# Altium schematic sheet FPGA_Config.SchDoc(FPGA_Config)
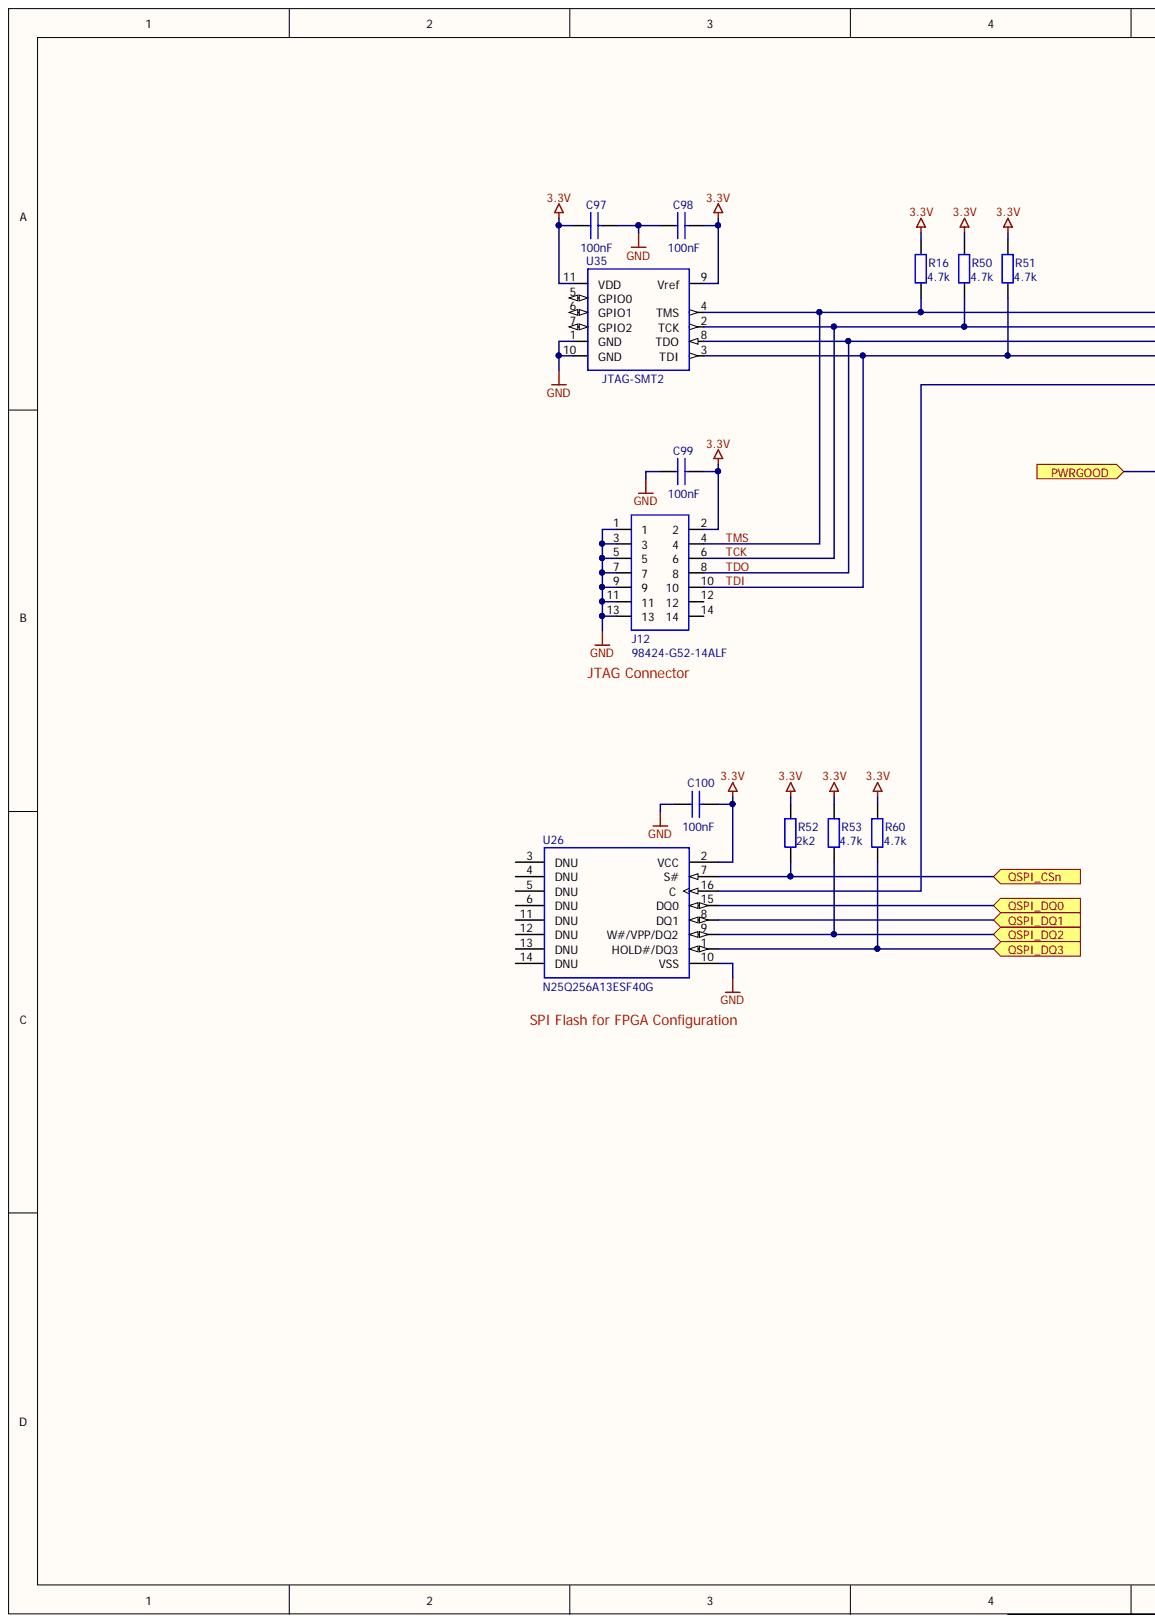
[diagram p0: FPGA_Config.SchDoc, left]
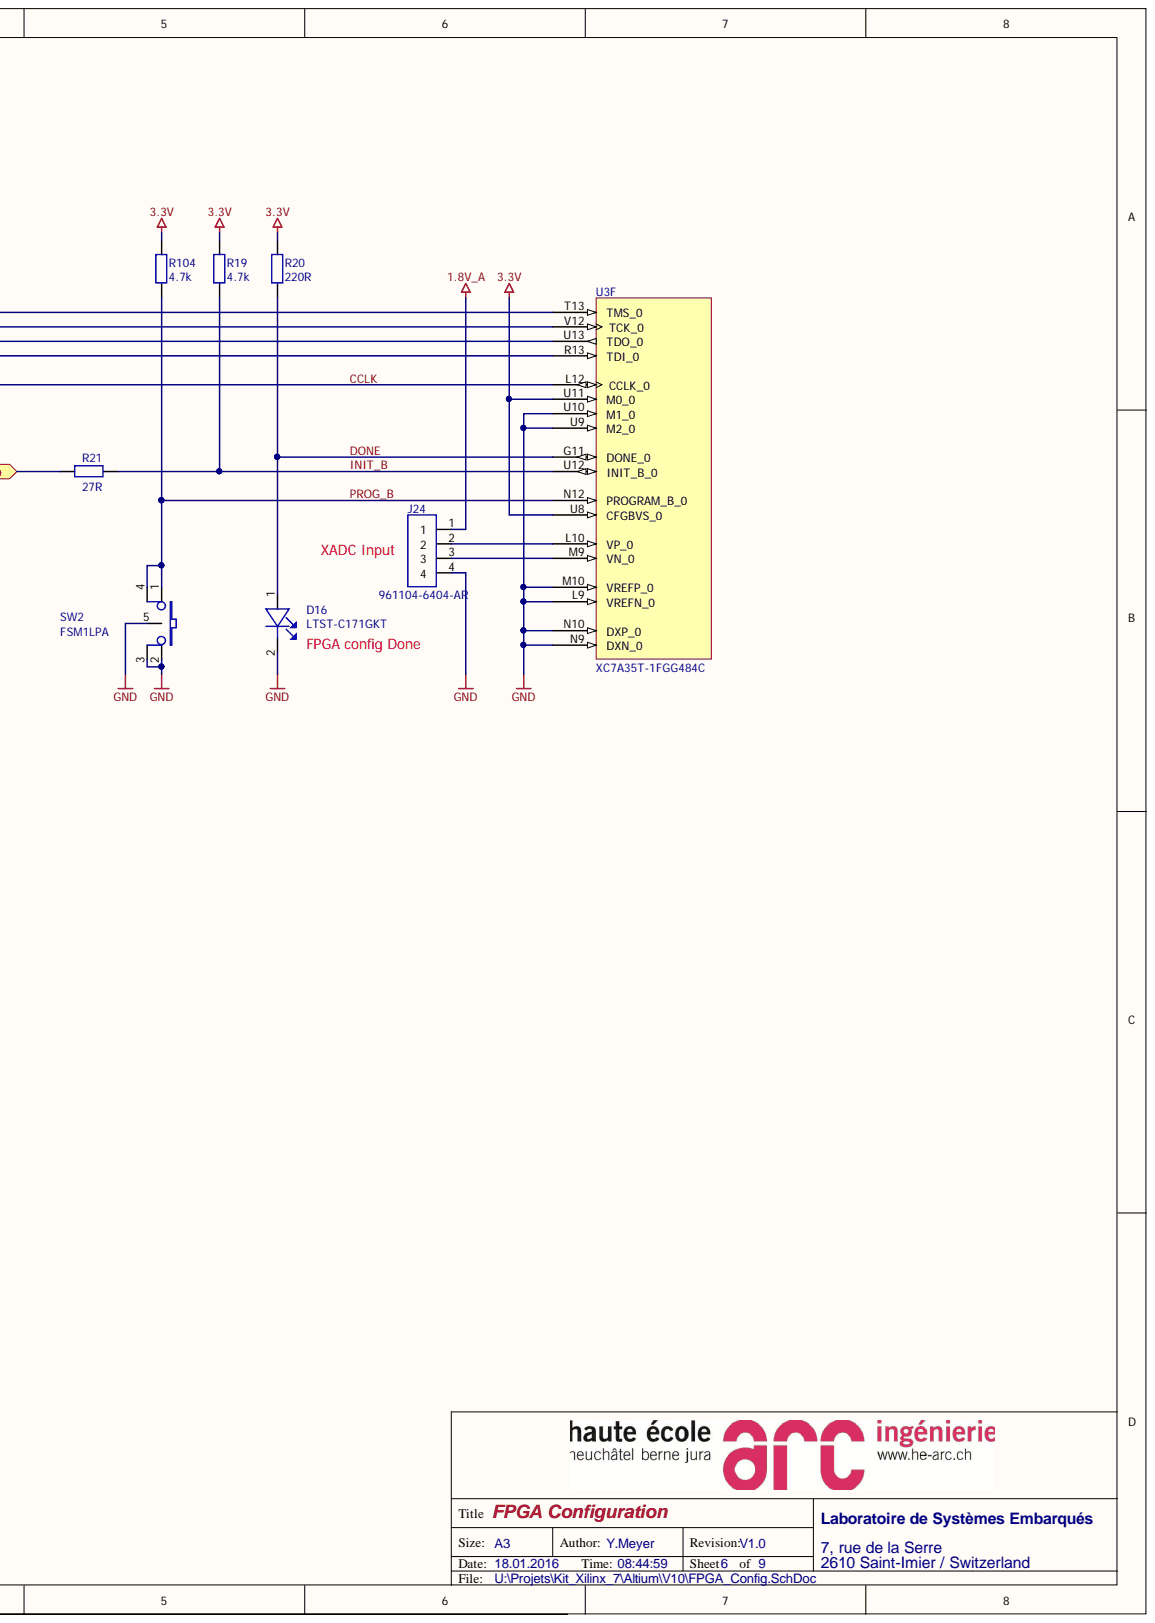
[diagram p1: FPGA_Config.SchDoc, right]

## Parts
C97  value 100nF  [060301]  (hidden comment: C0603C104Z3VAC7867)
C98  value 100nF  [060301]  (hidden comment: C0603C104Z3VAC7867)
C99  value 100nF  [060301]  (hidden comment: C0603C104Z3VAC7867)
C100  value 100nF  [060301]  (hidden comment: C0603C104Z3VAC7867)
D16  LTST-C171GKT  [0805_Led]
J12  98424-G52-14ALF  [J207JTAG_SMD]
J24  961104-6404-AR  [J104A101]
R16  value 4.7k  [060301]  (hidden comment: ERJ-3EKF4701V)
R19  value 4.7k  [060301]  (hidden comment: ERJ-3EKF4701V)
R20  value 220R  [060301]  (hidden comment: RC0603FR-07220RL)
R21  value 27R  [060301]  (hidden comment: RC0603FR-0727RL)
R50  value 4.7k  [060301]  (hidden comment: ERJ-3EKF4701V)
R51  value 4.7k  [060301]  (hidden comment: ERJ-3EKF4701V)
R52  value 2k2  [060301]  (hidden comment: RC0603FR-072K2L)
R53  value 4.7k  [060301]  (hidden comment: ERJ-3EKF4701V)
R60  value 4.7k  [060301]  (hidden comment: ERJ-3EKF4701V)
R104  value 4.7k  [060301]  (hidden comment: ERJ-3EKF4701V)
SW2  FSM1LPA  [FSM1LP]
U3  XC7A35T-1FGG484C  [FGG484]  (part F)
U26  N25Q256A13ESF40G  [SOP16]
U35  JTAG-SMT2  [JTAG-SMT2]

## Ports
PWRGOOD, QSPI_CSN, QSPI_DQ0, QSPI_DQ1, QSPI_DQ2, QSPI_DQ3

## Nets
1.8V_A: J24.1
3.3V: C97.1 C98.2 C99.2 C100.2 J12.2 R16.1 R19.1 R20.1 R50.1 R51.1 R52.1 R53.1 R60.1 R104.1 U3.U8(CFGBVS_0) U3.U11(M0_0) U26.2(VCC) U35.9(Vref) U35.11(VDD)
GND: C97.2 C98.1 C99.1 C100.1 D16.2 J12.1 J12.3 J12.5 J12.7 J12.9 J12.11 J12.13 J24.4 SW2.2 SW2.3 SW2.5 U3.L9(VREFN_0) U3.M10(VREFP_0) U3.N9(DXN_0) U3.N10(DXP_0) U3.U9(M2_0) U3.U10(M1_0) U26.10(VSS) U35.1(GND) U35.10(GND)
QSPI_CSN: R52.2 U26.7(S#) ; port QSPI_CSN
CCLK: U3.L12(CCLK_0) U26.16(C)
DONE: D16.1 R20.2 U3.G11(DONE_0)
INIT_B: R19.2 R21.2 U3.U12(INIT_B_0)
PROG_B: R104.2 SW2.1 SW2.4 U3.N12(PROGRAM_B_0)
PWRGOOD: R21.1 ; port PWRGOOD
QSPI_DQ0: U26.15(DQ0) ; port QSPI_DQ0
QSPI_DQ1: U26.8(DQ1) ; port QSPI_DQ1
QSPI_DQ2: R53.2 U26.9(W#/VPP/DQ2) ; port QSPI_DQ2
QSPI_DQ3: R60.2 U26.1(HOLD#/DQ3) ; port QSPI_DQ3
TCK: J12.6 R50.2 U3.V12(TCK_0) U35.2(TCK)
TDI: J12.10 R51.2 U3.R13(TDI_0) U35.3(TDI)
TDO: J12.8 U3.U13(TDO_0) U35.8(TDO)
TMS: J12.4 R16.2 U3.T13(TMS_0) U35.4(TMS)
NetJ24_2: J24.2 U3.L10(VP_0)
NetJ24_3: J24.3 U3.M9(VN_0)

Not connected: J12 12 14; U26 3(DNU) 4(DNU) 5(DNU) 6(DNU) 11(DNU) 12(DNU) 13(DNU) 14(DNU); U35 5(GPIO0) 6(GPIO1) 7(GPIO2)

## Library data of the parts
C97-C100 = CAPA: CAP .10UF 25V CERAMIC Y5V 0603; Component Kind: Standard; Supplier 1: Digi-Key; Supplier Part Number 1: 399-1100-2-ND; Library Name: EISI.SchLib
D16 = LED: LED GREEN CLEAR 0805 SMD; Component Kind: Standard; Supplier 1: Digi-Key; Supplier Part Number 1: 160-1423-1-ND; Library Name: EISI.SchLib
J12 = CON14A: CONN HEADER 14POS 2MM STR DL SMD; Component Kind: Standard; Supplier 1: Digi-Key; Supplier Part Number 1: 609-2643-1-ND; Library Name: EISI.SchLib
J24 = CON4: CONN HEADER VERT SGL 4POS GOLD; Component Kind: Standard; Supplier 1: Digi-Key; Supplier Part Number 1: 3M9449-ND; Library Name: EISI.SchLib
R16 R19 R50 R51 R53 R60 R104 = RES: RES 4.70K OHM 1/10W 1% 0603 SMD; Component Kind: Standard; Supplier 1: Digi-Key; Supplier Part Number 1: P4.70KHCT-ND; Library Name: EISI.SchLib
R20 = RES: RES 220 OHM 1/10W 1% 0603; Component Kind: Standard; Supplier 1: Digi-Key; Supplier Part Number 1: 311-220HRTR-ND; Library Name: EISI.SchLib
R21 = RES: RES SMD 27 OHM 1% 1/10W 0603; Component Kind: Standard; Revision: July-2002: Re-released for DXP Platform.; Supplier 1: Digi-Key; Supplier Part Number 1: 311-27.0HRCT-ND; Library Name: EISI.SchLib
R52 = RES: RES 2.20K OHM 1/10W 1% 0603 SMD; Component Kind: Standard; Supplier 1: Digi-Key; Supplier Part Number 1: 311-2.20KHRCT-ND; Library Name: EISI.SchLib
SW2 = FSM1LP: SWITCH TACT SPST RED W/GND SMD; Component Kind: Standard; Supplier 1: Digi-Key; Supplier Part Number 1: 450-1139-ND; Library Name: EISI.SchLib
U3 = XC7A35T-1FGG484C: Artix-7 FPGA, 250 User I/Os, 4 GTP, 484-Ball BGA, Speed Grade 1, Commercial Grade, Pb-Free; Manufacturer 1: Xilinx; Component Kind: Standard; Library Name: KitXilinx7.SCHLIB; Manufacturer Part Number 1: XC7A35T-1FGG484C; Supplier 1: Avnet Express; Supplier Part Number 1: XC7A35T-1FGG484C; Supplier Subtotal 1: 2140; Supplier Unit Price 1: 52.1952
U26 = N25Q256SF-16: IC FLASH 256MBIT 108MHZ 16SO; Component Kind: Standard; Supplier 1: Digi-Key; Supplier Part Number 1: 557-1575-5-ND; Library Name: EISI.SchLib
U35 = JTAG-SMT2: MOD PROGRAMMING JTAG-SMT2-NC; Component Kind: Standard; Supplier 1: Digi-Key; Supplier Part Number 1: 1286-1048-ND; Library Name: EISI.SchLib
